# Altium schematic sheet 102-002-00140-00,K12声音传感器V1.0原理图.SchDoc
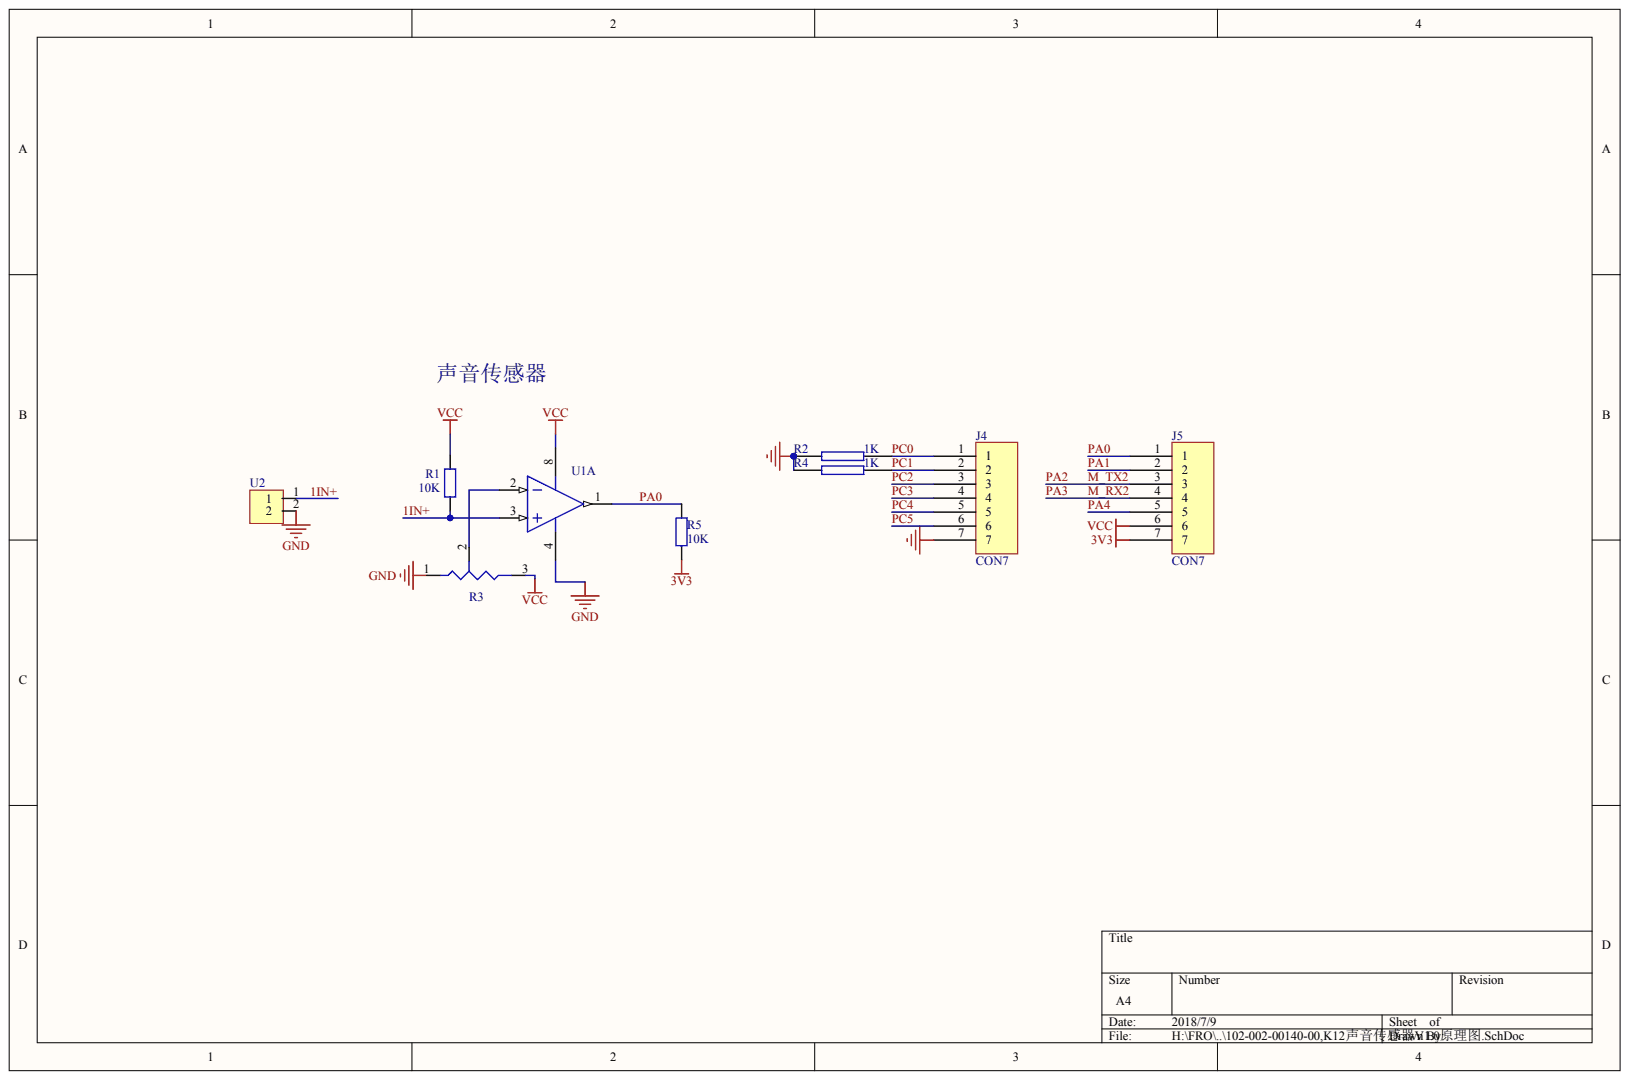
[diagram p0: 102-002-00140-00,K12声音传感器V1.0原理图.SchDoc]

## Parts
J4  CON7
J5  CON7
R1  10K
R2  1K
R3  RES_Ketiao  (value not drawn)
R4  1K
R5  10K
U1  LM393  (value not drawn)  (part A)
U2  MiTou  (value not drawn)

## Nets
3V3: J5.7 R5.1
GND: J4.7 R2.1 R3.1 R4.1 U1.4 U2.2
VCC: J5.6 R1.1 R3.3 U1.8
1IN+: R1.2 U1.3 U2.1
M_RX2: J5.4
M_TX2: J5.3
PA0: J5.1 R5.2 U1.1
PA1: J5.2
PA4: J5.5
PC0: J4.1 R2.2
PC1: J4.2 R4.2
PC2: J4.3
PC3: J4.4
PC4: J4.5
PC5: J4.6
NetR3_2: R3.2 U1.2

## Library data of the parts
R1 R5 = (no symbol name): wuliaoguige: 0805/10KΩ/F; wuliaobianma: 103-001-00103-01; wuliaomingchen: 贴片电阻
R2 R4 = (no symbol name): wuliaobianma: 103-001-00102-01; wuliaoguige: 0805/1KΩ/F; wuliaomingchen: 贴片电阻
R3 = (no symbol name): wuliaobianma: 103-008-00005-00; wuliaoguige: 3296W/103/带柄侧调; wuliaomingchen: 直插可调电位器
U1 = (no symbol name): wuliaobianma: 101-001-00032-00; wuliaoguige: LM393/SOP8; wuliaomingchen: 贴片芯片
U2 = (no symbol name): wuliaobianma: 199-008-00007-00; wuliaoguige: 6*5/电容式/带电容/驻极体; wuliaomingchen: 咪头
